# Altium schematic sheet 主控制板.SchDoc(主控制板)
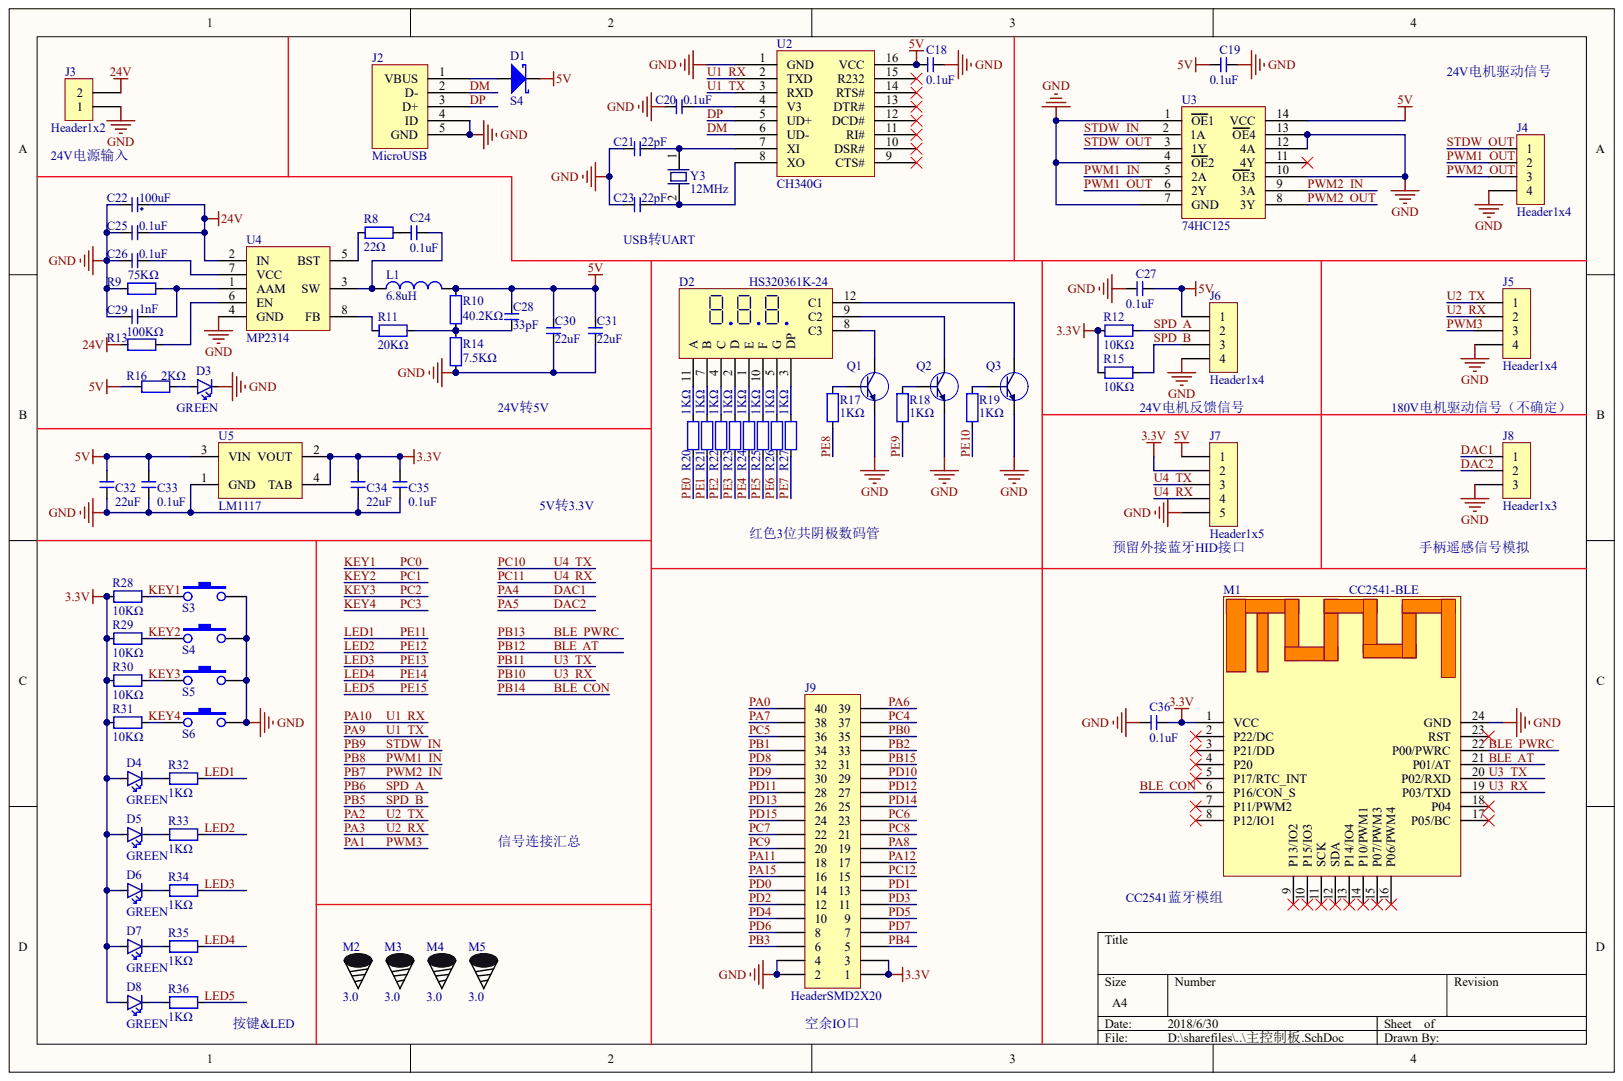
[diagram p0: 主控制板.SchDoc]

## Parts
C18  value 0.1uF  (hidden comment: Cap)
C19  value 0.1uF  (hidden comment: Cap)
C20  value 0.1uF  (hidden comment: Cap)
C21  value 22pF  (hidden comment: Cap)
C22  value 100uF  (hidden comment: X7R)
C23  value 22pF  (hidden comment: Cap)
C24  value 0.1uF  (hidden comment: Cap)
C25  value 0.1uF  (hidden comment: Cap)
C26  value 0.1uF  (hidden comment: Cap)
C27  value 0.1uF  (hidden comment: Cap)
C28  value 33pF  (hidden comment: Cap)
C29  value 1nF  (hidden comment: Cap)
C30  value 22uF  (hidden comment: Cap)
C31  value 22uF  (hidden comment: Cap)
C32  value 22uF  (hidden comment: Cap)
C33  value 0.1uF  (hidden comment: Cap)
C34  value 22uF  (hidden comment: Cap)
C35  value 0.1uF  (hidden comment: Cap)
C36  value 0.1uF  (hidden comment: Cap)
D1  S4
D2  HS320361K-24
D3  value GREEN  (hidden comment: LED)
D4  value GREEN  (hidden comment: LED)
D5  value GREEN  (hidden comment: LED)
D6  value GREEN  (hidden comment: LED)
D7  value GREEN  (hidden comment: LED)
D8  value GREEN  (hidden comment: LED)
J2  MicroUSB
J3  Header1x2
J4  Header1x4
J5  Header1x4
J6  Header1x4
J7  Header1x5
J8  Header1x3
J9  HeaderSMD2X20
L1  value 6.8uH  (hidden comment: inductor)
M1  CC2541-BLE
M2  M3  value 3.0
M3  M3  value 3.0
M4  M3  value 3.0
M5  value 3.0  (hidden comment: M3)
Q1  SS8050  (value not drawn)
Q2  SS8050  (value not drawn)
Q3  SS8050  (value not drawn)
R8  value 22Ω  (hidden comment: Res)
R9  value 75KΩ  (hidden comment: Res)
R10  value 40.2KΩ  (hidden comment: Res)
R11  value 20KΩ  (hidden comment: Res)
R12  value 10KΩ  (hidden comment: Res)
R13  value 100KΩ  (hidden comment: Res)
R14  value 7.5KΩ  (hidden comment: Res)
R15  value 10KΩ  (hidden comment: Res)
R16  value 2KΩ  (hidden comment: Res)
R17  value 1KΩ  (hidden comment: Res)
R18  value 1KΩ  (hidden comment: Res)
R19  value 1KΩ  (hidden comment: Res)
R20  value 1KΩ  (hidden comment: Res)
R21  value 1KΩ  (hidden comment: Res)
R22  value 1KΩ  (hidden comment: Res)
R23  value 1KΩ  (hidden comment: Res)
R24  value 1KΩ  (hidden comment: Res)
R25  value 1KΩ  (hidden comment: Res)
R26  value 1KΩ  (hidden comment: Res)
R27  value 1KΩ  (hidden comment: Res)
R28  value 10KΩ  (hidden comment: Res)
R29  value 10KΩ  (hidden comment: Res)
R30  value 10KΩ  (hidden comment: Res)
R31  value 10KΩ  (hidden comment: Res)
R32  value 1KΩ  (hidden comment: Res)
R33  value 1KΩ  (hidden comment: Res)
R34  value 1KΩ  (hidden comment: Res)
R35  value 1KΩ  (hidden comment: Res)
R36  value 1KΩ  (hidden comment: Res)
S3  KeySMT  (value not drawn)
S4  KeySMT  (value not drawn)
S5  KeySMT  (value not drawn)
S6  KeySMT  (value not drawn)
U2  CH340G
U3  74HC125
U4  MP2314
U5  LM1117
Y3  12M  value 12MHz

## Nets
3.3V: C34.2 C35.2 C36.1 D4.2 D5.2 D6.2 D7.2 D8.2 J7.2 J9.1 J9.3 M1.1(VCC) R12.1 R15.1 R28.1 R29.1 R30.1 R31.1 U5.2(VOUT) U5.4(TAB)
5V: C18.2 C19.1 C27.2 C28.2 C30.2 C31.2 C32.2 C33.2 D1.2 J6.1 J7.1 L1.2 R10.1 R16.2 U2.16(VCC) U3.14(VCC) U5.3(VIN)
24V: C22.1 C25.2 J3.2 R13.2 U4.2(IN)
GND: C18.1 C19.2 C20.2 C21.2 C22.2 C23.2 C25.1 C26.1 C27.1 C29.2 C30.1 C31.1 C32.1 C33.1 C34.1 C35.1 C36.2 D3.1 J2.4(ID) J2.5(GND) J3.1 J4.4 J5.4 J6.4 J7.5 J8.3 J9.2 J9.4 M1.24(GND) Q1.2 Q2.2 Q3.2 R9.2 R14.2 S3.2 S4.2 S5.2 S6.2 U2.1(GND) U3.1(OE1) U3.4(OE2) U3.7(GND) U3.10(OE3) U3.12(4A) U3.13(OE4) U4.4(GND) U5.1(GND)
BLE_AT: M1.21(P01/AT)
BLE_CON: M1.6(P16/CON_S)
BLE_PWRC: M1.22(P00/PWRC)
DAC1: J8.1
DAC2: J8.2
DM: J2.2(D-) U2.6(UD-)
DP: J2.3(D+) U2.5(UD+)
KEY1: R28.2 S3.1
KEY2: R29.2 S4.1
KEY3: R30.2 S5.1
KEY4: R31.2 S6.1
LED1: R32.1
LED2: R33.1
LED3: R34.1
LED4: R35.1
LED5: R36.1
PA0: J9.40
PA1: J5.3
PA2: J5.1
PA3: J5.2
PA6: J9.39
PA7: J9.38
PA8: J9.19
PA9: U2.3(RXD)
PA10: U2.2(TXD)
PA11: J9.18
PA12: J9.17
PA15: J9.16
PB0: J9.35
PB1: J9.34
PB2: J9.33
PB3: J9.6
PB4: J9.5
PB5: J6.3 R15.2
PB6: J6.2 R12.2
PB7: U3.9(3A)
PB8: U3.5(2A)
PB9: U3.2(1A)
PB10: M1.19(P03/TXD)
PB11: M1.20(P02/RXD)
PB15: J9.31
PC4: J9.37
PC5: J9.36
PC6: J9.23
PC7: J9.22
PC8: J9.21
PC9: J9.20
PC10: J7.3
PC11: J7.4
PC12: J9.15
PD0: J9.14
PD1: J9.13
PD2: J9.12
PD3: J9.11
PD4: J9.10
PD5: J9.9
PD6: J9.8
PD7: J9.7
PD8: J9.32
PD9: J9.30
PD10: J9.29
PD11: J9.28
PD12: J9.27
PD13: J9.26
PD14: J9.25
PD15: J9.24
PE0: R20.1
PE1: R21.1
PE2: R22.1
PE3: R23.1
PE4: R24.1
PE5: R25.1
PE6: R26.1
PE7: R27.1
PE8: R17.1
PE9: R18.1
PE10: R19.1
PWM1_OUT: J4.2 U3.6(2Y)
PWM2_OUT: J4.3 U3.8(3Y)
STDW_OUT: J4.1 U3.3(1Y)
NetC20_1: C20.1 U2.4(V3)
NetC21_1: C21.1 U2.7(XI) Y3.1
NetC23_1: C23.1 U2.8(XO) Y3.2
NetC24_1: C24.1 R8.1
NetC24_2: C24.2 L1.1 U4.3(SW)
NetC26_2: C26.2 U4.7(VCC)
NetC28_1: C28.1 R10.2 R11.1 R14.1
NetC29_1: C29.1 R9.1 U4.1(AAM)
NetD1_1: D1.1 J2.1(VBUS)
NetD2_1: D2.1(E) R24.2
NetD2_2: D2.2(D) R23.2
NetD2_3: D2.3(DP) R27.2
NetD2_4: D2.4(C) R22.2
NetD2_5: D2.5(G) R26.2
NetD2_7: D2.7(B) R21.2
NetD2_8: D2.8(C3) Q1.3
NetD2_9: D2.9(C2) Q2.3
NetD2_10: D2.10(F) R25.2
NetD2_11: D2.11(A) R20.2
NetD2_12: D2.12(C1) Q3.3
NetD3_2: D3.2 R16.1
NetD4_1: D4.1 R32.2
NetD5_1: D5.1 R33.2
NetD6_1: D6.1 R34.2
NetD7_1: D7.1 R35.2
NetD8_1: D8.1 R36.2
NetQ1_1: Q1.1 R17.2
NetQ2_1: Q2.1 R18.2
NetQ3_1: Q3.1 R19.2
NetR8_2: R8.2 U4.5(BST)
NetR11_2: R11.2 U4.8(FB)
NetR13_1: R13.1 U4.6(EN)

Not connected: M1 2(P22/DC) 3(P21/DD) 4(P20) 5(P17/RTC_INT) 7(P11/PWM2) 8(P12/IO1) 9(P13/IO2) 10(P15/IO3) 11(SCK) 12(SDA) 13(P14/IO4) 14(P10/PWM1) 15(P07/PWM3) 16(P06/PWM4) 17(P05/BC) 18(P04) 23(RST); U2 9(CTS#) 10(DSR#) 11(RI#) 12(DCD#) 13(DTR#) 14(RTS#) 15(R232); U3 11(4Y)

## Library data of the parts
C18-C21 C23-C36 = (no symbol name): 插位: SMT; 属性: ±10%/50V; 编码: *
C22 = (no symbol name): 插位: SMT; 属性: 贴片式 25V(105℃) ±20%; 编码: *
D2 = (no symbol name): 插位: HI; Value: *; 编码: *
D3-D8 S3-S6 U2 U3 Y3 = (no symbol name): 插位: SMT; 编码: *
  S3-S6 U2 U3: Value: *
L1 = (no symbol name): 型号: MHCI06030-XRXM-R8; 插位: SMT; 编码: *
Q1-Q3 = (no symbol name): 插位: SMT; 编码: 36504401; Value: 40V 1.5A
R8-R36 = (no symbol name): 插位: SMT; 属性: ±1%/1/10W; 编码: *
U4 = (no symbol name): Value: 4.5-24V,2A; 插位: SMT; 编码: *
U5 = (no symbol name): Value: 3.3V,800mA; 插位: SMT; 编码: *
D1 J2-J9 M1-M5 = (no symbol name)
  M2-M5: 插位: *; 编码: *
